# Altium schematic sheet Fibernet.SchDoc
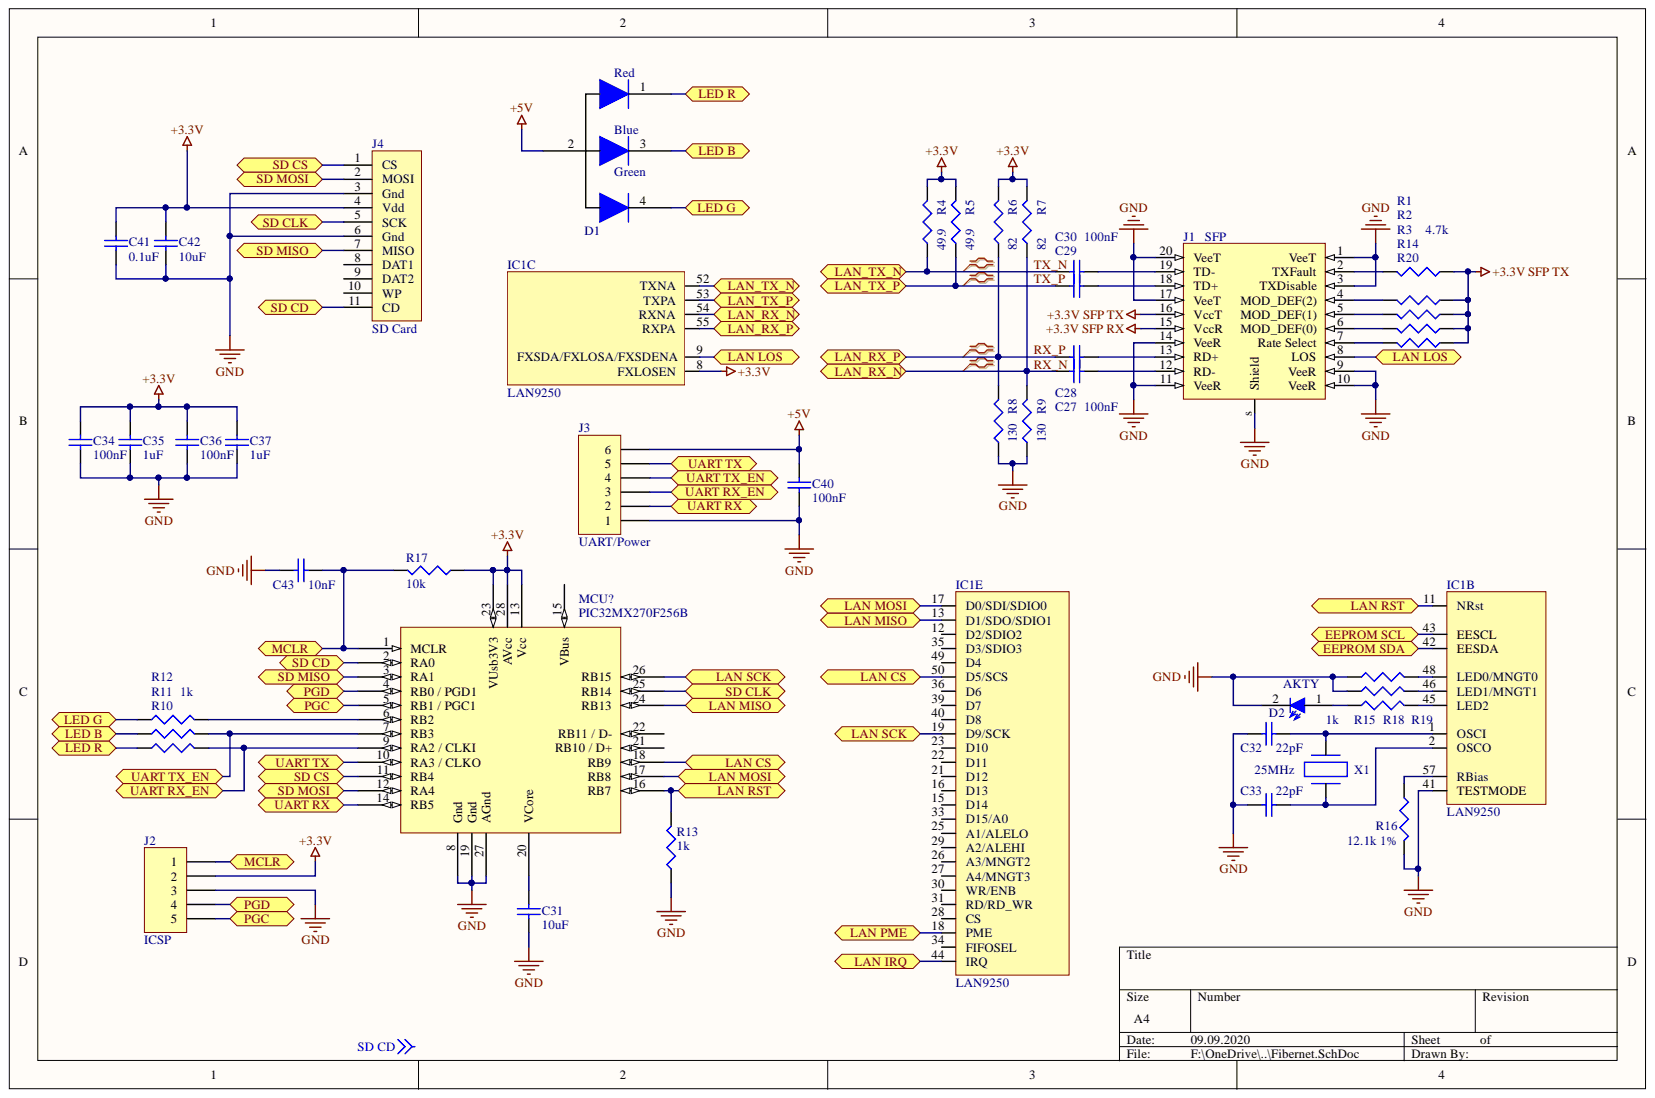
[diagram p0: Fibernet.SchDoc]

## Parts
C27  100nF  [0603]
C28  100nF  [0603]  (value not drawn)
C29  100nF  [0603]
C30  100nF  [0603]
C31  10uF  [0603]
C32  22pF  [0603]
C33  22pF  [0603]
C34  100nF  [0603]
C35  1uF  [0603]
C36  100nF  [0603]
C37  1uF  [0603]
C40  100nF  [0603]
C41  0.1uF  [0402]
C42  10uF  [0603]
C43  10nF  [0603]
D1  RGB LED Common anode  [1411]  (value not drawn)
D2  AKTY  [0603]
IC1  LAN9250  [QFN64]  (parts B,C,E)
J1  SFP  [SFP Con and Cage]
J2  ICSP  [HDR1X5]
J3  UART/Power  [HDR1X6]
J4  SD Card  [MEM2075]
MCU?  PIC32MX270F256B  [TSSOP28_Wide]  (value not drawn)
R1  4.7k  [0603]  (value not drawn)
R2  4.7k  [0603]  (value not drawn)
R3  4.7k  [0603]  (value not drawn)
R4  49.9  [0603]
R5  49.9  [0603]
R6  82  [0603]
R7  82  [0603]
R8  130  [0603]
R9  130  [0603]
R10  1k  [0603]
R11  1k  [0603]
R12  1k  [0603]
R13  1k  [0603]
R14  4.7k  [0603]
R15  1k  [0603]
R16  12.1k 1%  [0603]
R17  10k  [0603]
R18  1k  [0603]
R19  1k  [0603]  (value not drawn)
R20  4.7k  [0603]  (value not drawn)
X1  25MHz  [ECS-3225MV Oscillator]

## Ports
EEPROM SCL, EEPROM SDA, LAN CS, LAN IRQ, LAN LOS, LAN MISO, LAN MOSI, LAN PME, LAN RST, LAN SCK, LAN_RX_N, LAN_RX_P, LAN_TX_N, LAN_TX_P, LED B, LED G, LED R, MCLR, PGC, PGD, SD CD, SD CLK, SD CS, SD MISO, SD MOSI, UART RX, UART RX_EN, UART TX, UART TX_EN

## Nets
+3.3V: C34.2 C35.2 C36.2 C37.2 C41.2 C42.2 IC1.8(FXLOSEN) J2.2 J4.4(Vdd) MCU?.13(Vcc) MCU?.23(VUsb3V3) MCU?.28(AVcc) R4.1 R5.1 R6.1 R7.1 R17.1
+3.3V SFP RX: J1.15(VccR)
+3.3V SFP TX: J1.16(VccT) R1.2 R2.2 R3.2 R14.2 R20.2
+5V: C40.2 D1.2 J3.6
GND: C31.1 C32.1 C33.1 C34.1 C35.1 C36.1 C37.1 C40.1 C41.1 C42.1 C43.2 D2.2 IC1.41(TESTMODE) J1.1(VeeT) J1.3(TXDisable) J1.9(VeeR) J1.10(VeeR) J1.11(VeeR) J1.14(VeeR) J1.17(VeeT) J1.20(VeeT) J1.s(Shield) J2.3 J3.1 J4.3(Gnd) J4.6(Gnd) MCU?.8(Gnd) MCU?.19(Gnd) MCU?.27(AGnd) R8.2 R9.2 R13.2 R16.1 R18.2 R19.2
EEPROM SCL: IC1.43(EESCL) ; port EEPROM SCL
EEPROM SDA: IC1.42(EESDA) ; port EEPROM SDA
LAN CS: IC1.50(D5/SCS) MCU?.18(RB9) ; port LAN CS
LAN IRQ: IC1.44(IRQ) ; port LAN IRQ
LAN LOS: IC1.9(FXSDA/FXLOSA/FXSDENA) J1.8(LOS) ; port LAN LOS
LAN MISO: IC1.13(D1/SDO/SDIO1) MCU?.24(RB13) ; port LAN MISO
LAN MOSI: IC1.17(D0/SDI/SDIO0) MCU?.17(RB8) ; port LAN MOSI
LAN PME: IC1.18(PME) ; port LAN PME
LAN RST: IC1.11(NRst) MCU?.16(RB7) R13.1 ; port LAN RST
LAN SCK: IC1.19(D9/SCK) MCU?.26(RB15) ; port LAN SCK
LED B: D1.3 R11.2 ; port LED B
LED G: D1.4 R12.2 ; port LED G
LED R: D1.1 R10.2 ; port LED R
MCLR: C43.1 J2.1 MCU?.1(MCLR) R17.2 ; port MCLR
PGC: J2.5 MCU?.5(RB1_/_PGC1) ; port PGC
PGD: J2.4 MCU?.4(RB0_/_PGD1) ; port PGD
RX_N: C27.1 IC1.54(RXNA) R7.2 R9.1 ; port LAN_RX_N
RX_P: C28.1 IC1.55(RXPA) R6.2 R8.1 ; port LAN_RX_P
SD CD: J4.11(CD) MCU?.2(RA0) ; port SD CD
SD CLK: J4.5(SCK) MCU?.25(RB14) ; port SD CLK
SD CS: J4.1(CS) MCU?.11(RB4) ; port SD CS
SD MISO: J4.7(MISO) MCU?.3(RA1) ; port SD MISO
SD MOSI: J4.2(MOSI) MCU?.12(RA4) ; port SD MOSI
TX_N: C30.1 IC1.52(TXNA) R4.2 ; port LAN_TX_N
TX_P: C29.1 IC1.53(TXPA) R5.2 ; port LAN_TX_P
UART RX: J3.2 MCU?.14(RB5) ; port UART RX
UART RX_EN: J3.3 MCU?.9(RA2_/_CLKI) R10.1 ; port UART RX_EN
UART TX: J3.5 MCU?.10(RA3_/_CLKO) ; port UART TX
UART TX_EN: J3.4 MCU?.7(RB3) R11.1 ; port UART TX_EN
NetC27_2: C27.2 J1.12(RD-)
NetC28_2: C28.2 J1.13(RD+)
NetC29_2: C29.2 J1.18(TD+)
NetC30_2: C30.2 J1.19(TD-)
NetC31_2: C31.2 MCU?.20(VCore)
NetC32_2: C32.2 IC1.1(OSCI) X1.2
NetC33_2: C33.2 IC1.2(OSCO) X1.1
NetD2_1: D2.1 R15.2
NetIC1_45: IC1.45(LED2) R15.1
NetIC1_46: IC1.46(LED1/MNGT1) R18.1
NetIC1_48: IC1.48(LED0/MNGT0) R19.1
NetIC1_57: IC1.57(RBias) R16.2
NetJ1_2: J1.2(TXFault) R1.1
NetJ1_4: J1.4(MOD_DEF(2)) R14.1
NetJ1_5: J1.5(MOD_DEF(1)) R2.1
NetJ1_6: J1.6(MOD_DEF(0)) R3.1
NetJ1_7: J1.7(Rate_Select) R20.1
NetMCU?_6: MCU?.6(RB2) R12.1

Not connected: IC1 12(D2/SDIO2) 15(D14) 16(D13) 21(D12) 22(D11) 23(D10) 25(A1/ALELO) 26(A3/MNGT2) 27(A4/MNGT3) 28(CS) 29(A2/ALEHI) 30(WR/ENB) 31(RD/RD_WR) 33(D15/A0) 34(FIFOSEL) 35(D3/SDIO3) 36(D6) 39(D7) 40(D8) 49(D4); J4 8(DAT1) 9(DAT2) 10(WP); MCU? 15(VBus) 21(RB10_/_D+) 22(RB11_/_D-)

## Library data of the parts
C27-C37 C40-C43 = Cap: Component Kind: Standard; Library Name: stdLib.SCHLIB; Supplier 1: Digi-Key; Supplier 2: Digi-Key; Supplier 3: Digi-Key; Supplier 4: Digi-Key; Supplier 5: Digi-Key; Supplier 6: Digi-Key; Supplier 7: Digi-Key; Supplier 8: Digi-Key; Supplier 9: Digi-Key; Supplier 10: Digi-Key; Supplier 11: Digi-Key; Supplier 12: Digi-Key; Supplier 13: Digi-Key; Supplier 14: Digi-Key; Supplier 15: Digi-Key; Supplier 16: Digi-Key; Supplier 17: Digi-Key; Supplier 18: Digi-Key; Supplier 19: Digi-Key; Supplier Part Number 1: 1276-2044-1-ND; Supplier Part Number 2: 1276-1018-1-ND; Supplier Part Number 3: 1276-1182-1-ND; Supplier Part Number 4: 1276-1009-1-ND; Supplier Part Number 5: 1276-1098-1-ND; Supplier Part Number 6: 1276-1119-1-ND; Supplier Part Number 7: 490-12737-1-ND; Supplier Part Number 8: 1276-1183-1-ND; Supplier Part Number 9: 587-1249-1-ND; Supplier Part Number 10: 1276-CL10B104KB8NNNLCT-ND; Supplier Part Number 11: 399-1085-1-ND; Supplier Part Number 12: 1276-1021-1-ND; Supplier Part Number 13: 587-2985-1-ND; Supplier Part Number 14: 490-14603-1-ND; Supplier Part Number 15: 311-3700-1-ND; Supplier Part Number 16: 311-1042-1-ND; Supplier Part Number 17: 311-1415-1-ND; Supplier Part Number 18: 1276-1907-1-ND; Supplier Part Number 19: 587-4321-1-ND
  C27-C37 C40 C43: Supplier 20: Digi-Key; Supplier 21: Digi-Key; Supplier 22: Digi-Key; Supplier Part Number 20: 1276-6767-1-ND; Supplier Part Number 21: 1276-7060-1-ND; Supplier Part Number 22: 311-1084-1-ND
D1 = RGB LED: RGB LED Invered; Component Kind: Standard; Library Name: stdLib.SCHLIB
D2 = LED: Component Kind: Standard; Library Name: stdLib.SCHLIB; Supplier 1: Digi-Key; Supplier 2: Digi-Key; Supplier 3: Digi-Key; Supplier 4: Digi-Key; Supplier Part Number 1: 732-4979-1-ND; Supplier Part Number 2: 732-4971-1-ND; Supplier Part Number 3: 732-4966-1-ND; Supplier Part Number 4: 732-4981-1-ND
IC1 = LAN9250: 10/100 Ethernet controller & PHY; Component Kind: Standard; ComponentLink1Description: Datasheet; ComponentLink1URL: https://ww1.microchip.com/downloads/en/DeviceDoc/00001913A.pdf; Library Name: stdLib.SCHLIB; Supplier 1: Digi-Key; Supplier Part Number 1: LAN9250/ML-ND
J1 = SFP Connector: SFP Connector with cage; Component Kind: Standard; Library Name: stdLib.SCHLIB; Supplier 1: Digi-Key; Supplier 2: Digi-Key; Supplier Part Number 1: UE75-A20-6000TCT-ND; Supplier Part Number 2: U77-A1118-200TCT-ND
J2 = Header 5: Header, 5-Pin; Component Kind: Standard; LatestRevisionDate: 17-Jul-2002; LatestRevisionNote: Re-released for DXP Platform.; Library Name: Miscellaneous Connectors.IntLib; Signal Integrity: Connector; Publisher: Altium Limited
J3 = Header 6: Header, 6-Pin; Component Kind: Standard; LatestRevisionDate: 17-Jul-2002; LatestRevisionNote: Re-released for DXP Platform.; Library Name: Miscellaneous Connectors.IntLib; Signal Integrity: Connector; Publisher: Altium Limited
MCU? = PIC32MX2xxFxxxB: 32Bit pic with usb; Component Kind: Standard; Library Name: stdLib.SCHLIB; Supplier 1: Digi-Key; Supplier Part Number 1: PIC32MX270F256B-I/SS-ND
R1-R20 = Resistor: Component Kind: Standard; Library Name: stdLib.SCHLIB; Supplier 1: Digi-Key; Supplier 2: Digi-Key; Supplier 3: Digi-Key; Supplier 4: Digi-Key; Supplier 5: Digi-Key; Supplier 6: Digi-Key; Supplier 7: Digi-Key; Supplier 8: Digi-Key; Supplier 9: Digi-Key; Supplier 10: Digi-Key; Supplier 11: Digi-Key; Supplier 12: Digi-Key; Supplier 13: Digi-Key; Supplier 14: Digi-Key; Supplier 15: Digi-Key; Supplier 16: Digi-Key; Supplier 17: Digi-Key; Supplier 18: Digi-Key; Supplier 19: Digi-Key; Supplier 20: Digi-Key; Supplier 21: Digi-Key; Supplier 22: Digi-Key; Supplier 23: Digi-Key; Supplier 24: Digi-Key; Supplier 25: Digi-Key; Supplier 26: Digi-Key; Supplier 27: Digi-Key; Supplier 28: Digi-Key; Supplier 29: Digi-Key; Supplier 30: Digi-Key; Supplier 31: Digi-Key; Supplier 32: Digi-Key; Supplier 33: Digi-Key; Supplier 34: Digi-Key; Supplier 35: Digi-Key; Supplier 36: Digi-Key; Supplier 37: Digi-Key; Supplier 38: Digi-Key; Supplier 39: Digi-Key; Supplier 40: Digi-Key; Supplier 41: Digi-Key; Supplier 42: Arrow; Supplier Part Number 1: RHM10DCT-ND; Supplier Part Number 2: 696-1834-1-ND; Supplier Part Number 3: RMCF0603JT3K90CT-ND; Supplier Part Number 4: RHM1.0KDCT-ND; Supplier Part Number 5: RHM100DCT-ND; Supplier Part Number 6: RMCF0603FT54K9CT-ND; Supplier Part Number 7: RMCF0603FT40K2CT-ND; Supplier Part Number 8: P200LCT-ND; Supplier Part Number 9: 311-1.0KJRCT-ND; Supplier Part Number 10: 311-200JRCT-ND; Supplier Part Number 11: 311-300JRCT-ND; Supplier Part Number 12: YAG3356CT-ND; Supplier Part Number 13: 311-220GRCT-ND; Supplier Part Number 14: 311-220KGRCT-ND; Supplier Part Number 15: 311-1.0KGRCT-ND; Supplier Part Number 16: 311-200KHRCT-ND; Supplier Part Number 17: A102451-ND; Supplier Part Number 18: 311-2.00KHRCT-ND; Supplier Part Number 19: 311-10KGRCT-ND; Supplier Part Number 20: 311-1.00LRCT-ND; Supplier Part Number 21: 311-220JRCT-ND; Supplier Part Number 22: 311-2.2KJRCT-ND; Supplier Part Number 23: 311-4.7KJRCT-ND; Supplier Part Number 24: 311-10.0KLRCT-ND; Supplier Part Number 25: 311-12KJRCT-ND; Supplier Part Number 26: YAG3226CT-ND; Supplier Part Number 27: 311-75KJRCT-ND; Supplier Part Number 28: 311-200KJRCT-ND; Supplier Part Number 29: 311-499KLRCT-ND; Supplier Part Number 30: YAG6087CT-ND; Supplier Part Number 31: 696-1677-1-ND; Supplier Part Number 32: 541-3127-6-ND; Supplier Part Number 33: 311-47.0HRCT-ND; Supplier Part Number 34: 311-470HRCT-ND; Supplier Part Number 35: 311-470KGRCT-ND; Supplier Part Number 36: 311-100KGRCT-ND; Supplier Part Number 37: 311-5.23KHRCT-ND; Supplier Part Number 38: 311-52.3KHRCT-ND; Supplier Part Number 39: 311-22KGRCT-ND; Supplier Part Number 40: 311-15.8KHRCT-ND; Supplier Part Number 41: 311-7.15KHRCT-ND; Supplier Part Number 42: RC0603FR-072K2L
X1 = Quarz crystal: Component Kind: Standard; Library Name: stdLib.SCHLIB; Supplier 1: Digi-Key; Supplier Part Number 1: SER4069TR-ND
J4 = SD Card: Component Kind: Standard; Library Name: stdLib.SCHLIB; Supplier 1: Digi-Key; Supplier 2: Digi-Key; Supplier Part Number 1: 609-3956-1-ND; Supplier Part Number 2: 2073-MEM2075-00-140-01-ACT-ND
